# Altium schematic sheet STM32F405开发板v2.2_电源.SchDoc
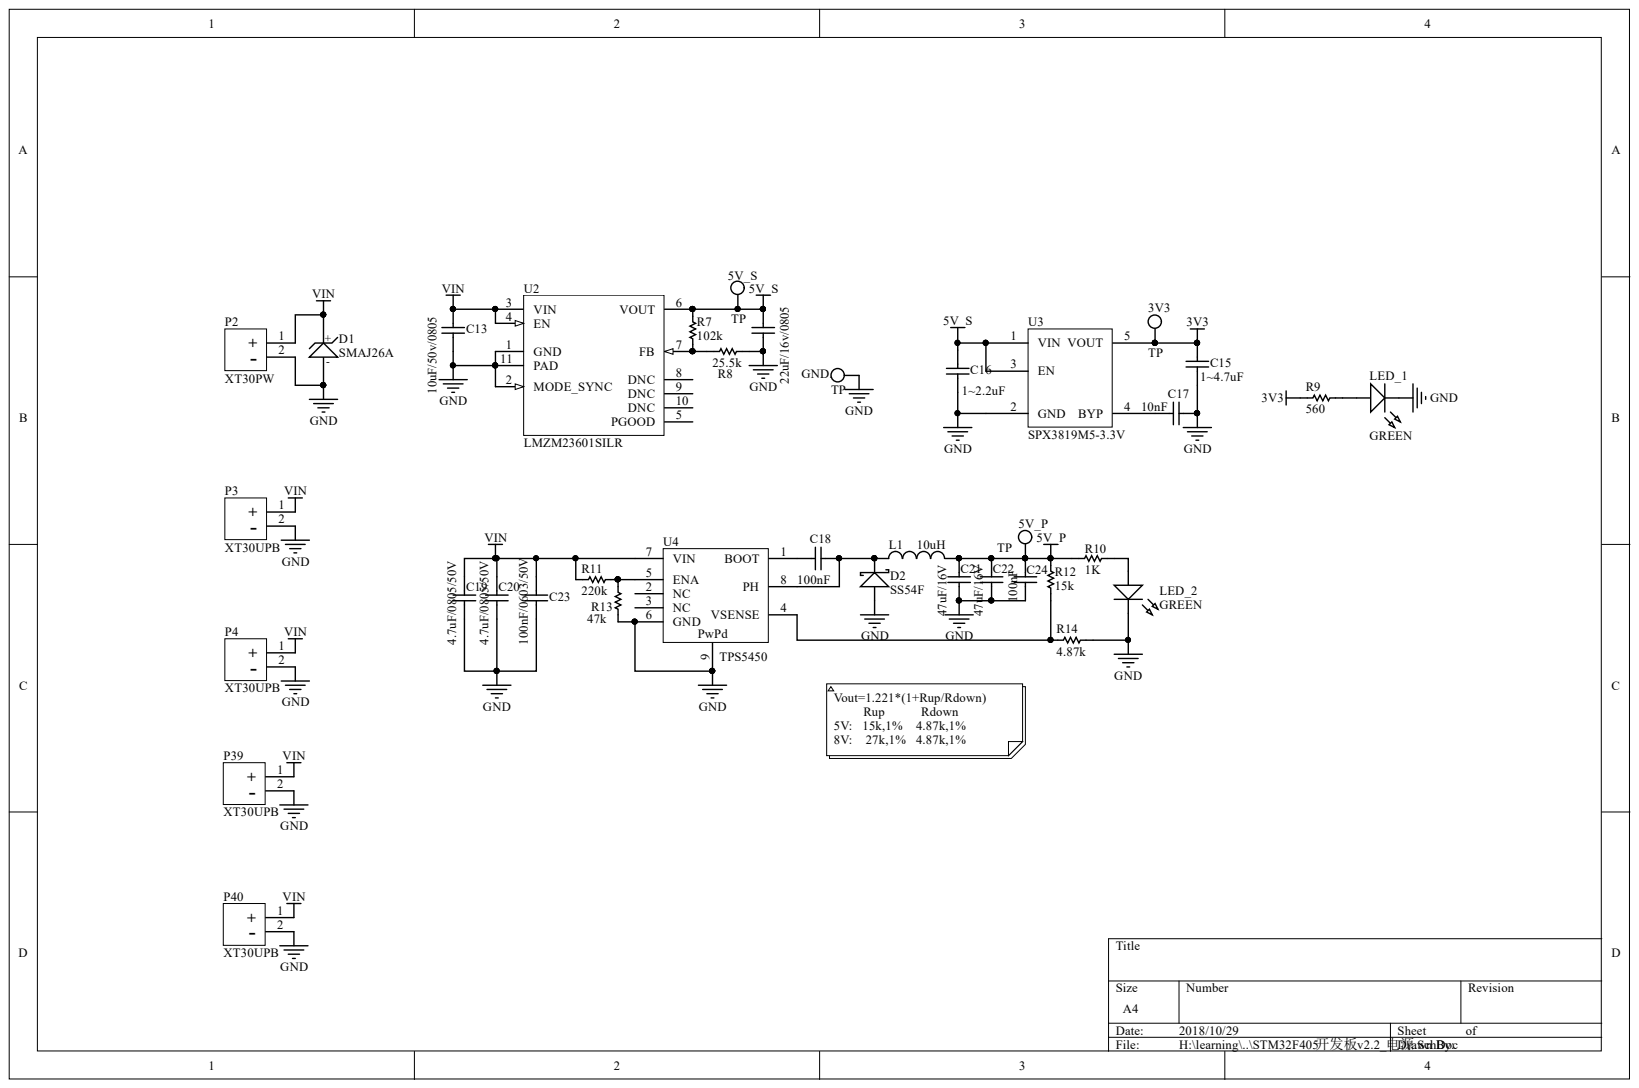
[diagram p0: STM32F405开发板v2.2_电源.SchDoc]

## Parts
3V3  TP  [TP_SMT]
5V_P  TP  [TP_SMT]
5V_S  TP  [TP_SMT]
C13  10uF/50v/0805  [0805]
C14  22uF/16v/0805  [0805]
C15  1~4.7uF  [0402]
C16  1~2.2uF  [0402]
C17  10nF  [0402]
C18  100nF  [0402]
C19  4.7uF/0805/50V  [0805]
C20  4.7uF/0805/50V  [0805]
C21  47uF/16V  [0805]
C22  47uF/16V  [0805]
C23  100nF/0603/50V  [0402]
C24  100nF  [0402]
D1  SMAJ26A  [SMA/DO-214AC]
D2  SS54F  [SMA/DO-214AC]
GND.  TP  [TP_VIA]
L1  10uH  [0630L]
LED_1  GREEN  [0603LED_G]
LED_2  GREEN  [0603LED_G]
P2  XT30PW  [XT30PW-Male]
P3  XT30UPB  [XT30立式]
P4  XT30UPB  [XT30立式]
P39  XT30UPB  [XT30立式]
P40  XT30UPB  [XT30立式]
R7  102k  [0402R]
R8  25.5k  [0402R]
R9  560  [0603R]
R10  1K  [0603R]
R11  220k  [0402R]
R12  15k  [0402R]
R13  47k  [0402R]
R14  4.87k  [0402R]
U2  LMZM23601SILR  [SIL0010A]
U3  SPX3819M5-3.3V  [sot-23-5 l]
U4  TPS5450  [SOIC8E]

## Nets
3V3: 3V3.1 C15.1 R9.2 U3.5(VOUT)
5V_P: 5V_P.1 C21.2 C22.2 C24.2 L1.2 R10.1 R12.2
5V_S: 5V_S.1 C14.2 C16.2 R7.2 U2.6(VOUT) U3.1(VIN) U3.3(EN)
GND: C13.1 C14.1 C15.2 C16.1 C17.1 C19.1 C20.1 C21.1 C22.1 C23.1 C24.1 D1.1 D2.1 GND..1 LED_1.2 LED_2.2 P2.2 P3.2 P4.2 P39.2 P40.2 R8.1 R13.2 R14.1 U2.1(GND) U2.2(MODE_SYNC) U2.11(PAD) U3.2(GND) U4.6(GND) U4.9
VIN: C13.2 C19.2 C20.2 C23.2 D1.2 P2.1 P3.1 P4.1 P39.1 P40.1 R11.2 U2.3(VIN) U2.4(EN) U4.7(VIN)
NetC17_2: C17.2 U3.4(BYP)
NetC18_1: C18.1 U4.1(BOOT)
NetC18_2: C18.2 D2.2 L1.1 U4.8(PH)
NetLED_1_1: LED_1.1 R9.1
NetLED_2_1: LED_2.1 R10.2
NetR7_1: R7.1 R8.2 U2.7(FB)
NetR11_1: R11.1 R13.1 U4.5(ENA)
NetR12_1: R12.1 R14.2 U4.4(VSENSE)

Not connected: U2 5(PGOOD) 8(DNC) 9(DNC) 10(DNC); U4 2(NC) 3(NC)

## Library data of the parts
3V3 5V_P 5V_S GND. = TP: Test Point; Component Kind: Standard; Library Name: MyComponents_v2.4.IntLib
C13-C24 = Cap: Capacitor; Value: 100pF; Component Kind: Standard; LatestRevisionDate: 17-Jul-2002; LatestRevisionNote: Re-released for DXP Platform.; Library Name: MyComponents_v2.4.IntLib; PackageDescription: Radial Cap, Thru-Hole; 2 Leads; 0.3 in Pin Spacing; PackageReference: RAD-0.3; Published: 8-Jun-2000; Signal Integrity: Cap; Simulation: CAP; Publisher: Altium Limited
D1 = TVS(单向）: Component Kind: Standard; Library Name: MyComponents_v2.4.IntLib
D2 = D Schottky: Schottky Diode; Code_JEDEC: DO-214-AA; Component Kind: Standard; LatestRevisionDate: 17-Jul-2002; LatestRevisionNote: Re-released for DXP Platform.; Library Name: MyComponents_v2.4.IntLib; PackageDescription: DO-214-AA/SMB; 2 C-Bend Leads; Body 5.3 x 3.6 mm, inc. leads (LxW); PackageReference: SMB; Published: 8-Jun-2000; Signal Integrity: D Schottky; Simulation: SKYDIODE; Publisher: Altium Limited
L1 = Inductor: Code_IEC: 1005; Value: 10mH; Code_JEITA: 0402; Component Kind: Standard; Description: Inductor; LatestRevisionDate: 29-May-2009; LatestRevisionNote: IPC-7351 Footprint Added.; Library Name: MyComponents_v2.4.IntLib; PackageDescription: Chip Inductor; PackageReference: 0402-A; Published: 8-Jun-2000; Signal Integrity: Inductor; Simulation: INDUCTOR; Publisher: Altium Limited
LED_1 LED_2 = LED: Typical RED, GREEN, YELLOW, AMBER GaAs LED; Component Kind: Standard; LatestRevisionDate: 17-Jul-2002; LatestRevisionNote: Re-released for DXP Platform.; Library Name: MyComponents_v2.4.IntLib; PackageDescription: SM LED; 2 Flat Leads; PackageReference: 3.2X1.6X1.1; PackageVersion: Feb-2002; Published: 8-Jun-2000; Simulation: LED2; Publisher: Altium Limited
P2-P4 P39 P40 = XT30/60/90: Component Kind: Standard; Library Name: MyComponents_v2.4.IntLib
R7-R9 R11-R14 = Res1: Resistor; Value: 1K; Component Kind: Standard; LatestRevisionDate: 17-Jul-2002; LatestRevisionNote: Re-released for DXP Platform.; Library Name: MyComponents_v2.4.IntLib; PackageDescription: Axial Device, Thru-Hole; 2 Leads; 0.3 in Pin Spacing; PackageReference: AXIAL-0.3; Published: 8-Jun-2000; Signal Integrity: Res; Simulation: RESISTOR; Publisher: Altium Limited
R10 = Res1: Resistor; Component Kind: Standard; LatestRevisionDate: 17-Jul-2002; LatestRevisionNote: Re-released for DXP Platform.; Library Name: MyComponents_v2.4.IntLib; PackageDescription: Axial Device, Thru-Hole; 2 Leads; 0.3 in Pin Spacing; PackageReference: AXIAL-0.3; Published: 8-Jun-2000; Signal Integrity: Res; Simulation: RESISTOR; Value: 1K; Publisher: Altium Limited
U2 = LMZM23601SILR: Imported; Manufacturer: Texas Instruments; Component Kind: Standard; Library Name: MyComponents_v2.4.IntLib; PartNumber: LMZM23601SILR; RefDes: RefDes; TYPE: TYPE; Value: Value
U3 = SPX5205M5-3.3V: Component Kind: Standard; Library Name: MyComponents_v2.4.IntLib
U4 = TPS5450: Component Kind: Standard; Library Name: MyComponents_v2.4.IntLib
